# Altium schematic sheet ESP_MCU.SchDoc
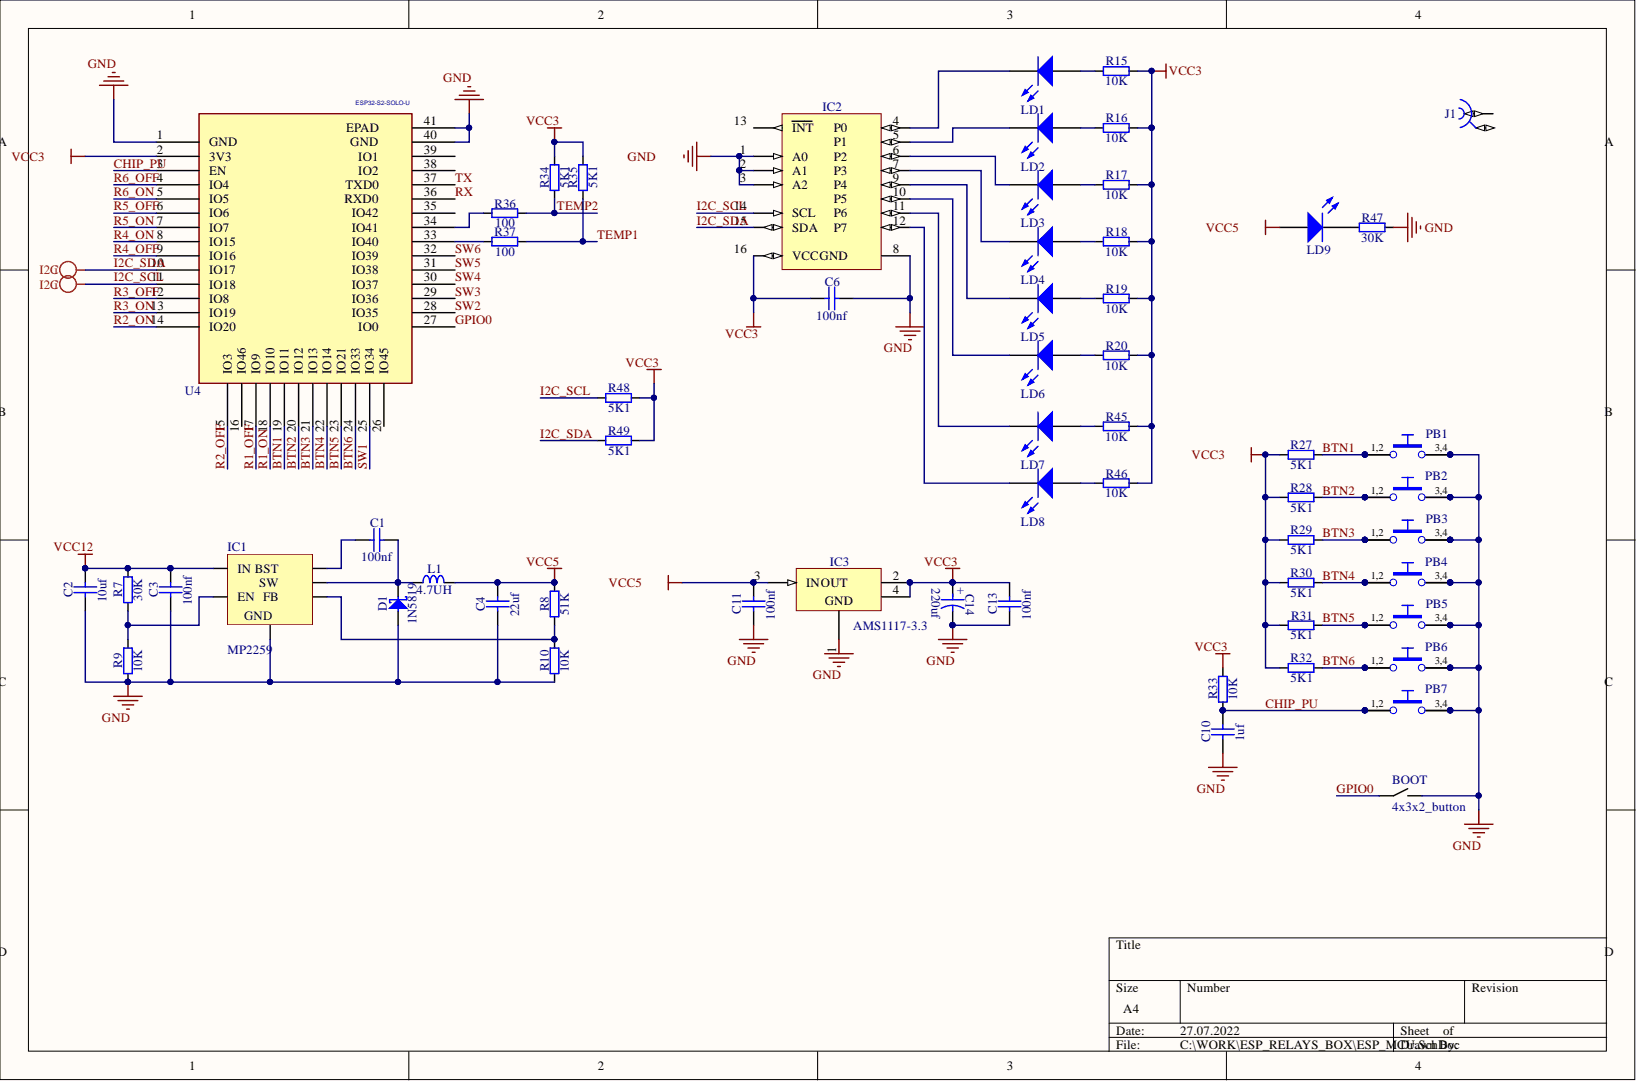
[diagram p0: ESP_MCU.SchDoc]

## Parts
BOOT  4x3x2_button  [4x3x2_button]
C1  100nf  [CAP_0603]
C2  10uf  [CAP_3216_NP]
C3  100nf  [CAP_0603]
C4  22uf  [CAP_3216_NP]
C6  100nf  [CAP_0603]
C10  1uf  [CAP_0603]
C11  100nf  [CAP_0603]
C13  100nf  [CAP_0603]
C14  220uf  [CAP_SOLID_5x9]
D1  1N5819  [SOD2713X115N]
IC1  MP2259  [SOT95P280X100-6N]
IC2  PCF8574T/3  [SOIC127P1030X265-16N]  (value not drawn)
IC3  AMS1117-3.3  [SOT230P700X180-4N]
J1  SMA  [HUBER-SUHNER_85 SMA-50-0-101/111 NE]  (value not drawn)
L1  4.7UH  [INDC2520X10N_1008]
LD1  Everlight 17-21-GHC-YR1S2-3T  [0805_LED_GREEN]  (value not drawn)
LD2  Everlight 17-21-GHC-YR1S2-3T  [0805_LED_GREEN]  (value not drawn)
LD3  Everlight 17-21-GHC-YR1S2-3T  [0805_LED_GREEN]  (value not drawn)
LD4  Everlight 17-21-GHC-YR1S2-3T  [0805_LED_GREEN]  (value not drawn)
LD5  Everlight 17-21-GHC-YR1S2-3T  [0805_LED_GREEN]  (value not drawn)
LD6  Everlight 17-21-GHC-YR1S2-3T  [0805_LED_GREEN]  (value not drawn)
LD7  Everlight 17-21-GHC-YR1S2-3T  [0805_LED_GREEN]  (value not drawn)
LD8  Everlight 17-21-GHC-YR1S2-3T  [0805_LED_GREEN]  (value not drawn)
LD9  Everlight 17-21-GHC-YR1S2-3T  [0805_LED_GREEN]  (value not drawn)
PB1  Push Button  [PB_ALPS_SKHHDTA010]  (value not drawn)
PB2  Push Button  [PB_ALPS_SKHHDTA010]  (value not drawn)
PB3  Push Button  [PB_ALPS_SKHHDTA010]  (value not drawn)
PB4  Push Button  [PB_ALPS_SKHHDTA010]  (value not drawn)
PB5  Push Button  [PB_ALPS_SKHHDTA010]  (value not drawn)
PB6  Push Button  [PB_ALPS_SKHHDTA010]  (value not drawn)
PB7  Push Button  [PB_ALPS_SKHHDTA010]  (value not drawn)
R7  30K  [RES_0603]
R8  51K  [RES_0603]
R9  10K  [RES_0603]
R10  10K  [RES_0603]
R15  10K  [RES_0603]
R16  10K  [RES_0603]
R17  10K  [RES_0603]
R18  10K  [RES_0603]
R19  10K  [RES_0603]
R20  10K  [RES_0603]
R27  5K1  [RES_0603]
R28  5K1  [RES_0603]
R29  5K1  [RES_0603]
R30  5K1  [RES_0603]
R31  5K1  [RES_0603]
R32  5K1  [RES_0603]
R33  10K  [RES_0603]
R34  5K1  [RES_0603]
R35  5K1  [RES_0603]
R36  100  [RES_0603]
R37  100  [RES_0603]
R45  10K  [RES_0603]
R46  10K  [RES_0603]
R47  30K  [RES_0603]
R48  5K1  [RES_0603]
R49  5K1  [RES_0603]
U4  ESP32-S2-SOLO-U  [ESP32-S2-SOLO]

## Nets
GND: BOOT.2 C2.1 C3.1 C4.1 C6.2 C10.1 C11.1 C13.1 C14.2 D1.2 IC1.2(FB) IC2.1(A0) IC2.2(A1) IC2.3(A2) IC2.8 IC3.1 PB1.3 PB1.4 PB2.3 PB2.4 PB3.3 PB3.4 PB4.3 PB4.4 PB5.3 PB5.4 PB6.3 PB6.4 PB7.3 PB7.4 R9.1 R10.1 R47.2 U4.1(GND) U4.40 U4.41
VCC3: C6.1 C13.2 C14.1 IC2.16 IC3.2 IC3.4 R15.2 R16.2 R17.2 R18.2 R19.2 R20.2 R27.1 R28.1 R29.1 R30.1 R31.1 R32.1 R33.2 R34.2 R35.2 R45.2 R46.2 R48.2 R49.2 U4.2(3V3)
VCC5: C4.2 C11.2 IC3.3(IN_OUT) L1.2 LD9.2 R8.2
VCC12: C2.2 C3.2 IC1.5(IN_BST) R7.2
BTN1: PB1.1 PB1.2 R27.2 U4.19(IO11)
BTN2: PB2.1 PB2.2 R28.2 U4.20(IO12)
BTN3: PB3.1 PB3.2 R29.2 U4.21(IO13)
BTN4: PB4.1 PB4.2 R30.2 U4.22(IO14)
BTN5: PB5.1 PB5.2 R31.2 U4.23(IO21)
BTN6: PB6.1 PB6.2 R32.2 U4.24(IO33)
CHIP_PU: C10.2 PB7.1 PB7.2 R33.1 U4.3(EN)
GPIO0: BOOT.1 U4.27
I2C_SCL: IC2.14(SCL) R48.1 U4.11(IO18)
I2C_SDA: IC2.15(SDA) R49.1 U4.10(IO17)
R1_OFF: U4.17(IO9)
R1_ON: U4.18(IO10)
R2_OFF: U4.15(IO3)
R2_ON: U4.14(IO20)
R3_OFF: U4.12(IO8)
R3_ON: U4.13(IO19)
R4_OFF: U4.9(IO16)
R4_ON: U4.8(IO15)
R5_OFF: U4.6(IO6)
R5_ON: U4.7(IO7)
R6_OFF: U4.4(IO4)
R6_ON: U4.5(IO5)
RX: U4.36
SW1: U4.25(IO34)
SW2: U4.28
SW3: U4.29
SW4: U4.30
SW5: U4.31
SW6: U4.32
TEMP1: R35.1 R37.2
TEMP2: R34.1 R36.2
TX: U4.37
NetC1_1: C1.1 IC1.1
NetC1_2: C1.2 D1.1 IC1.6 L1.1
NetIC1_3: IC1.3 R8.1 R10.2
NetIC1_4: IC1.4(EN) R7.1 R9.2
NetIC2_4: IC2.4 LD1.1
NetIC2_5: IC2.5 LD2.1
NetIC2_6: IC2.6 LD3.1
NetIC2_7: IC2.7 LD4.1
NetIC2_9: IC2.9 LD5.1
NetIC2_10: IC2.10 LD6.1
NetIC2_11: IC2.11 LD7.1
NetIC2_12: IC2.12 LD8.1
NetLD1_2: LD1.2 R15.1
NetLD2_2: LD2.2 R16.1
NetLD3_2: LD3.2 R17.1
NetLD4_2: LD4.2 R18.1
NetLD5_2: LD5.2 R19.1
NetLD6_2: LD6.2 R20.1
NetLD7_2: LD7.2 R45.1
NetLD8_2: LD8.2 R46.1
NetLD9_1: LD9.1 R47.1
NetR36_1: R36.1 U4.34
NetR37_1: R37.1 U4.33

Not connected: IC2 13(INT); J1 1 2; U4 16(IO46) 26(IO45) 35 38 39

## Library data of the parts
BOOT = SW_4x3x2: Database Table Name: MISC; GoodsBriefName: RC_SW_1KEY_BUTTON_SMD_3X4X2MM_2PIN; GoodsID: 59502; Код: 11; Case: 4x3x2; Component Kind: Standard; Part Number: SW_4x3x2; Pin Count: 2; Value: 4x3x2_button; Library Name: MIPS_COMPONENTS_LIB.DbLib
C1 C3 C6 C11 C13 = C0603_100NF: Non-polarised capacitor; Database Table Name: CAP; GoodsBriefName: RC_C_0603_100NF_1PCS; GoodsID: 47802; Voltage: 50V; Код: 2; Case: 0603; Component Kind: Standard; Part Number: C0603_100NF; Pin Count: 2; Value: 100nf; Library Name: MIPS_COMPONENTS_LIB.DbLib
C2 = C1206_10UF: Non-polarised capacitor; Database Table Name: CAP; Voltage: 25V; Код: 41; Case: 1206; Component Kind: Standard; Part Number: C1206_10UF; Pin Count: 2; Value: 10uf; Library Name: MIPS_COMPONENTS_LIB.DbLib
C4 = C1206_22UF: Non-polarised capacitor; Database Table Name: CAP; GoodsBriefName: RC_C_1206_22UF; GoodsID: 52843; Voltage: 10V; Код: 21; Case: 1206; Component Kind: Standard; Part Number: C1206_22UF; Pin Count: 2; Value: 22uf; Library Name: MIPS_COMPONENTS_LIB.DbLib
C10 = C0603_1UF: Non-polarised capacitor; Database Table Name: CAP; Voltage: 16V; Код: 40; Case: 0603; Component Kind: Standard; Part Number: C0603_1UF; Pin Count: 2; Value: 1uf; Library Name: MIPS_COMPONENTS_LIB.DbLib
C14 = CS_220UF_16V_5x9: Polarised capacitor; Database Table Name: CAP; GoodsID: 58669; Voltage: 16V; Код: 39; Case: 5x9H; Component Kind: Standard; Part Number: CS_220UF_16V_5x9; Pin Count: 2; Value: 220uf; Library Name: MIPS_COMPONENTS_LIB.DbLib
D1 = D_5819_SOD323: Schottky Diode; Database Table Name: D; GoodsBriefName: RC_D_1N5819_SOD323; GoodsID: 52854; Код: 5; Case: sod-323; Component Kind: Standard; Part Number: D_5819_SOD323; Pin Count: 2; Value: 1N5819; Library Name: MIPS_COMPONENTS_LIB.DbLib
IC1 = IC_MP2259: a monolithic integrated stepdown switch mode converter with an internal  power MOSFET.; Database Table Name: IC; Value: MP2259DJ-LF-Z; Код: 35; Case: SOT23-6; Component Kind: Standard; Part Number: IC_MP2259; Pin Count: 6; Library Name: MIPS_COMPONENTS_LIB.DbLib
IC2 = PCF8574T/3: Remote 8-bit I/O Expander For I2C Bus; Manufacturer: NXP SEMICONDUCTORS; Database Table Name: Analog & Interface; Case: SOIC16W; ComponentHeight: 2.65mm; Component Kind: Standard; Component Type: Standard; CreateDate: 23.08.2012; Device: PCF8574T/3; HelpURL: \\cern.ch\dfs\Applications\Altium\Datasheets\PCF8574T/3.pdf; LatestRevisionDate: 23.08.2012; Library Name: ICs And Semiconductors.DbLib; Manufacturer Part Number: PCF8574T/3; Mounted: Yes; PackageDescription: SOIC 16, Pitch 1.27mm - Body 7.5x10.3mm, IPC Medium Density; Part Description: Remote 8-bit I/O Expander For I2C Bus; Part Number: PCF8574T/3; Pin Count: 16; SMD: Yes; Socket: No; Table: Analog & Interface; Author: CERN DEM JLC
IC3 = IC_AMS1117-3.3: 3-Terminal Regulator 1=GND 2=OUT 3=IN 4=OUT; Database Table Name: IC; GoodsBriefName: RC_IC_AMS1117-3-3V; GoodsID: 47817; Код: 22; Case: SOT-223; Component Kind: Standard; Part Number: IC_AMS1117-3.3; Pin Count: 3; Value: AMS1117-3.3; Library Name: MIPS_COMPONENTS_LIB.DbLib
J1 = CONN_COAX_SMA_90_FEMALE: SMA adapter to 5cm coaxial with IPX; Manufacturer: HUBER+SUHNER; Manufacturer Part Number: 85_SMA-50-0-101/111_NE; Part Description: SMA 50 Ohms Right Angle PCB Jack; Database Table Name: CONN; Table: Miscellaneous; Value: SMA_EDGE_FEMALE; Код: 34; ComponentHeight: 9.6mm; Component Kind: Standard; Component Type: Standard; CreateDate: 20.04.2010; Family: SMA; HelpURL: \\cern.ch\dfs\Applications\Altium\Datasheets\HUBER-SUHNER_85 SMA-50-0-101-111 NE.pdf; LatestRevisionDate: 27.04.2010; Mounted: Yes; Part Number: CONN_COAX_SMA_90_FEMALE; Pin Count: 5; SMD: No; Socket: No; Status: None; Author: CERN DEM JLC; Library Name: MIPS_COMPONENTS_LIB.DbLib
L1 = IND_4.7UH_2520: Inductor; Database Table Name: IND; GoodsBriefName: RC_INDUCTOR_2520_4-7UH; GoodsID: 59491; Код: 4; Case: 2520; Component Kind: Standard; Part Number: IND_4.7UH_2520; Pin Count: 2; Value: 4.7UH; Library Name: MIPS_COMPONENTS_LIB.DbLib
LD1-LD9 = LED_0805_GREEN: SMD mono-color Chip LED, Red; Database Table Name: MISC; Код: 32; Case: 0805; Component Kind: Standard; Part Number: LED_0805_GREEN; Pin Count: 2; Value: Everlight 17-21-GHC-YR1S2-3T; Library Name: MIPS_COMPONENTS_LIB.DbLib
PB1-PB7 = SW_6x6xH_TSA06141: Push-Button Switch SPDT; Manufacturer: ALPS; Manufacturer Part Number: SKHHDTA010; Part Description: 12VDC 50mA, 6x6mm Model, 13mm Stem Height Vertical Pushbutton Switch, ALPS SKHH Series; Database Table Name: MISC; Table: Switches; Код: 31; Case: 6x6; ComponentHeight: 13mm; Component Kind: Standard; Component Type: Standard; CreateDate: 26.09.2013; Family: Push Button; HelpURL: \\cern.ch\dfs\Applications\Altium\Datasheets\PB_ALPS_SKHHDTA010.pdf; LatestRevisionDate: 26.09.2013; Mounted: Yes; PackageDescription: 6x6mm Model, 13mm Stem Height Vertical Pushbutton Switch, ALPS SKHH Series; Part Number: SW_6x6xH_TSA06141; Pin Count: 2; SMD: No; Socket: No; Status: None; Value: TSA06141; Author: CERN DEM JLC; Library Name: MIPS_COMPONENTS_LIB.DbLib
R7 R47 = R0603_30K_1%: Resistor; Database Table Name: res; GoodsBriefName: RC_R_0603_30KOM_1PERCENT; GoodsID: 60643; Код: 32; Case: 0603; Component Kind: Standard; Part Number: R0603_30K_1%; Pin Count: 2; Value: 30K; Library Name: MIPS_COMPONENTS_LIB.DbLib
R8 = R0603_51K_1%: Resistor; Database Table Name: res; GoodsBriefName: RC_R_0603_51KOM_1PERCENT; GoodsID: 48799; Код: 12; Case: 0603; Component Kind: Standard; Part Number: R0603_51K_1%; Pin Count: 2; Value: 51K; Library Name: MIPS_COMPONENTS_LIB.DbLib
R9 R10 R15-R20 R33 R45 R46 = R0603_10K_1%: Resistor; Database Table Name: res; GoodsBriefName: RC_R_0603_10KOM_1PERCENT_1PCS; GoodsID: 59406; Код: 10; Case: 0603; Component Kind: Standard; Part Number: R0603_10K_1%; Pin Count: 2; Value: 10K; Library Name: MIPS_COMPONENTS_LIB.DbLib
R27-R32 R34 R35 R48 R49 = R0603_5K1_1%: Resistor; Database Table Name: res; GoodsBriefName: RC_R_0603_5-1KOM_5PERCENT; GoodsID: 47801; Код: 9; Case: 0603; Component Kind: Standard; Part Number: R0603_5K1_1%; Pin Count: 2; Value: 5K1; Library Name: MIPS_COMPONENTS_LIB.DbLib
R36 R37 = R0603_100_5%: Resistor; Database Table Name: res; Код: 54; Case: 0603; Component Kind: Standard; Part Number: R0603_100_5%; Pin Count: 2; Value: 100; Library Name: MIPS_COMPONENTS_LIB.DbLib
U4 = MODULE_ESP32-S2-SOLO: Database Table Name: MODULES; Код: 7; Case: module; Component Kind: Standard; Part Number: MODULE_ESP32-S2-SOLO; Pin Count: 41; Value: ESP32-S2-SOLO-U; Library Name: MIPS_COMPONENTS_LIB.DbLib
